# Altium schematic sheet Schematic.SchDoc
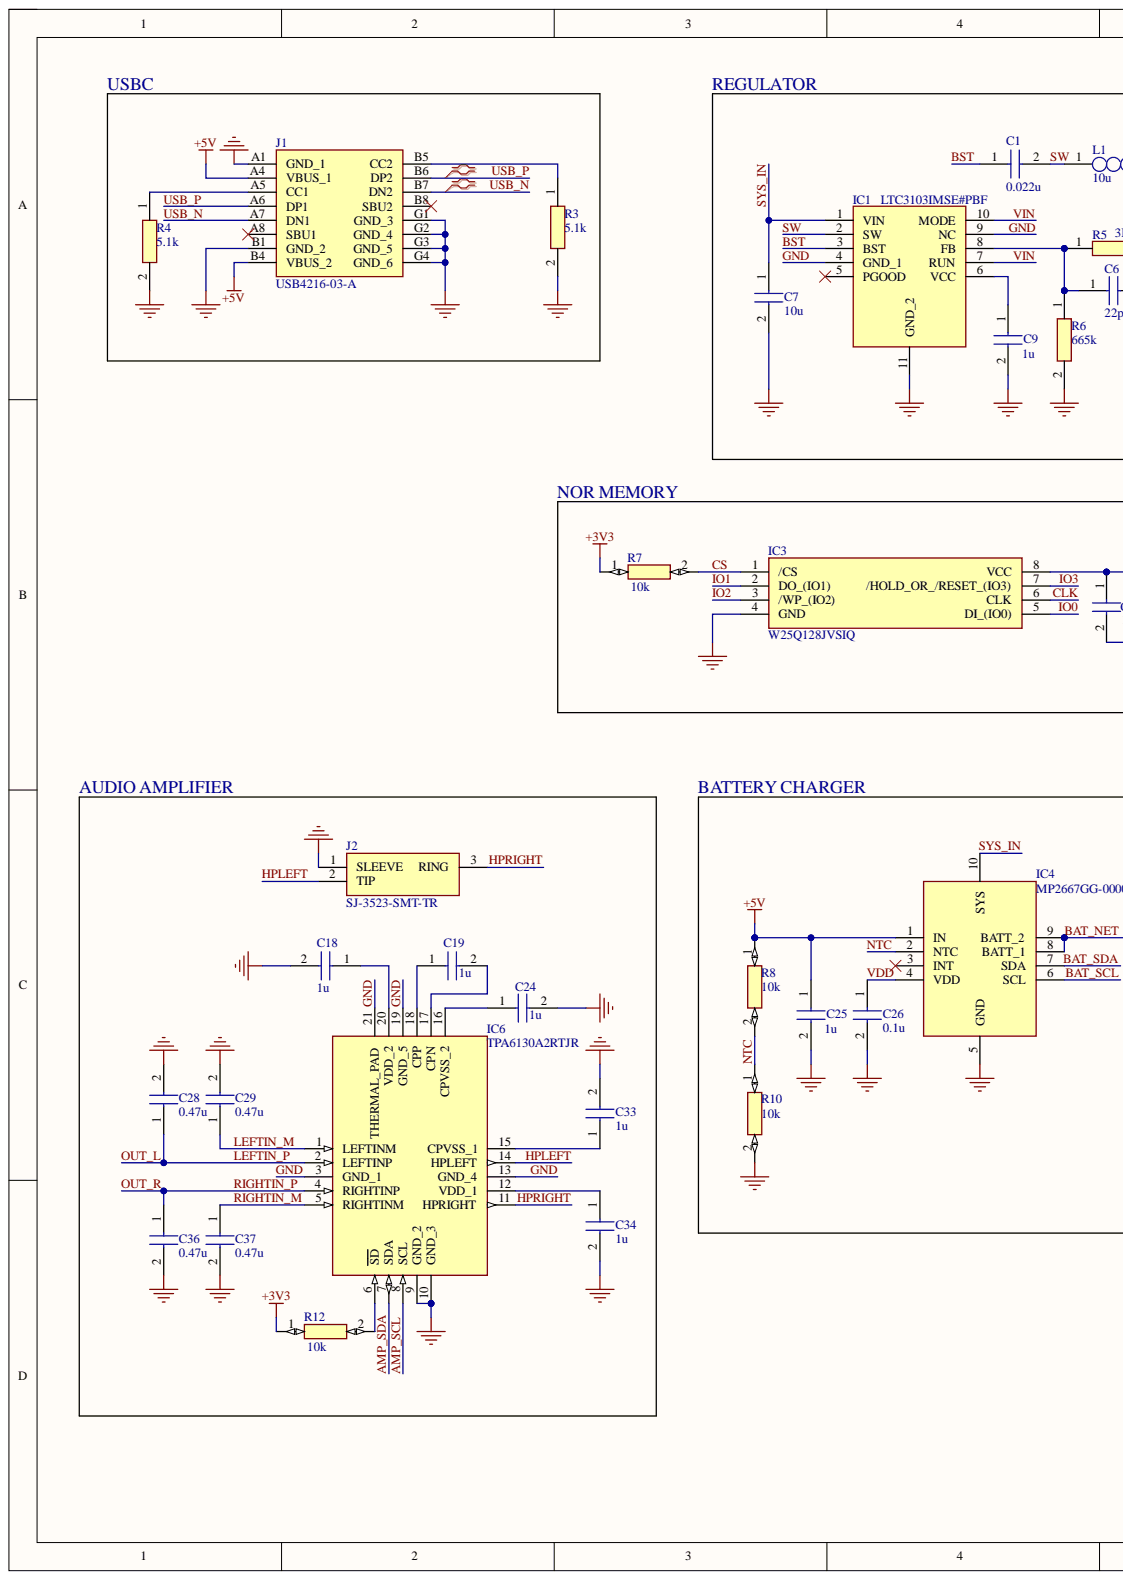
[diagram p0: Schematic.SchDoc, left]
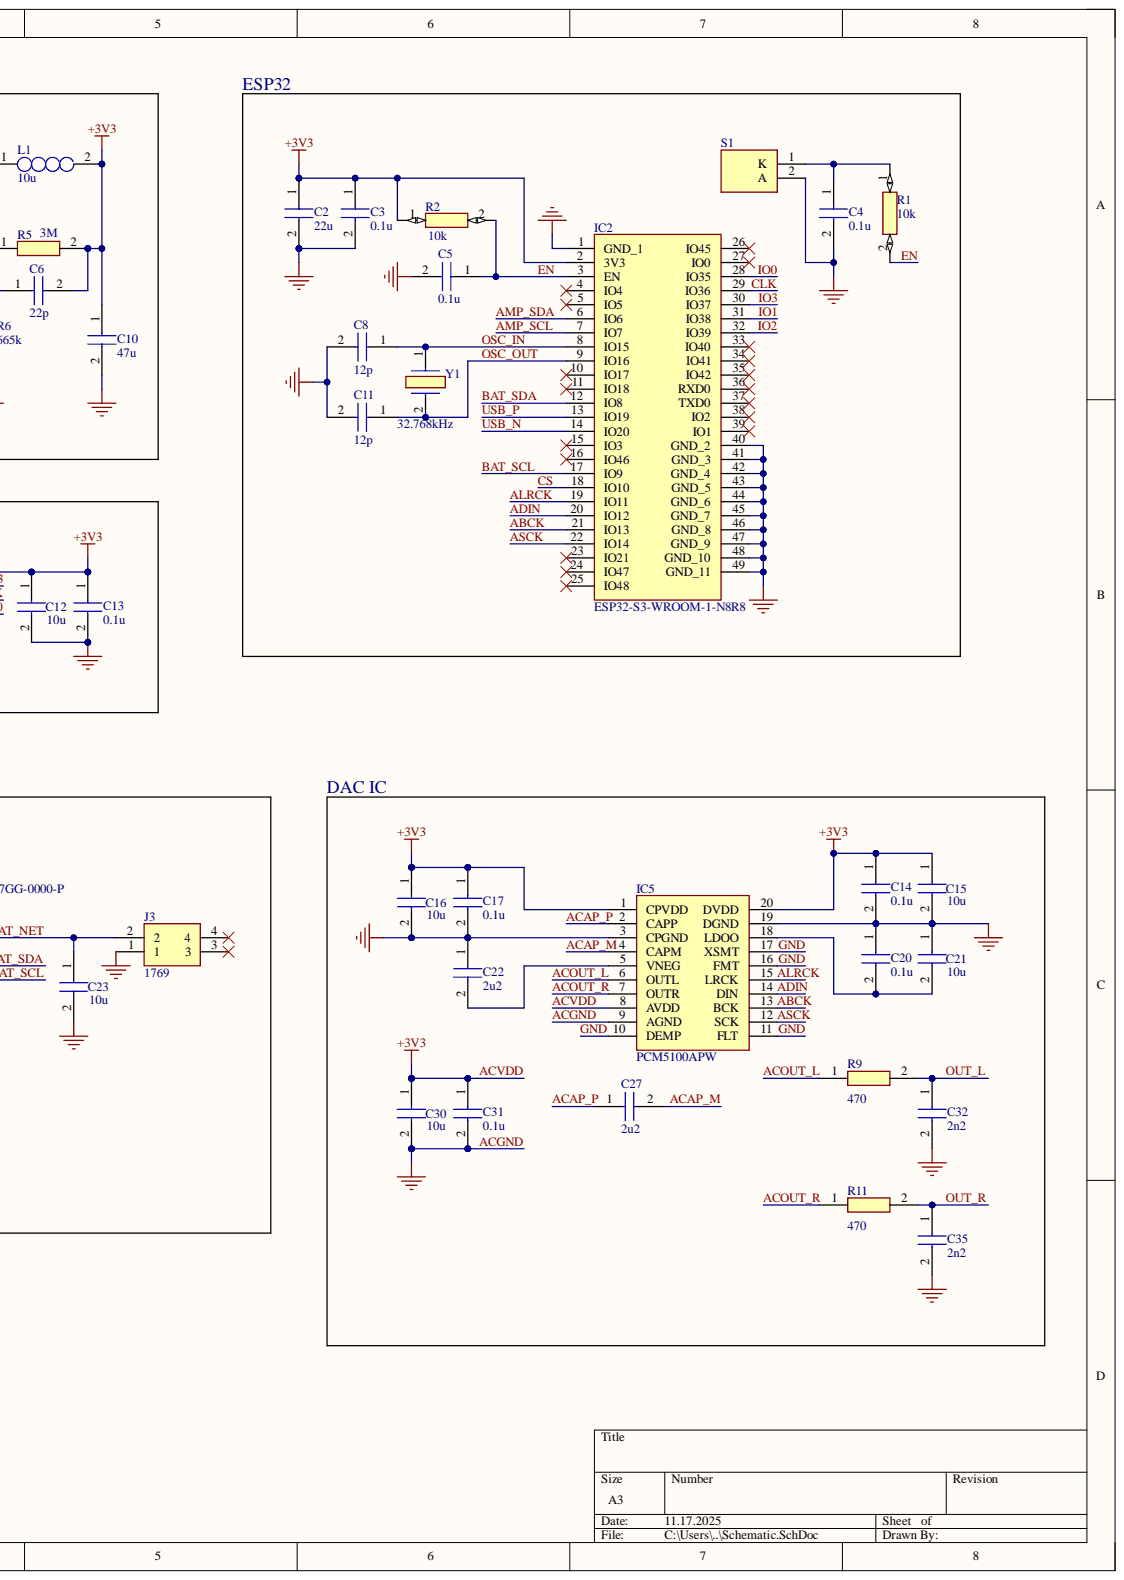
[diagram p1: Schematic.SchDoc, right]

## Parts
C1  0.022u  [CAPC1005X56N]
C2  22u  [MBASx32x]
C3  0.1u  [CAPC1005X55N]
C4  0.1u  [CAPC1005X55N]
C5  0.1u  [CAPC1005X55N]
C6  22p  [CAPC1005X56N]
C7  10u  [GRM18x]
C8  12p  [CAPC1005X70N]
C9  1u  [CAPC1608X90N]
C10  47u  [GRM18x]
C11  12p  [CAPC1005X70N]
C12  10u  [GRM18x]
C13  0.1u  [CAPC1005X55N]
C14  0.1u  [CAPC1005X55N]
C15  10u  [GRM18x]
C16  10u  [GRM18x]
C17  0.1u  [CAPC1005X55N]
C18  1u  [CAPC1608X90N]
C19  1u  [CAPC1608X90N]
C20  0.1u  [CAPC1005X55N]
C21  10u  [GRM18x]
C22  2u2  [GRM15x]
C23  10u  [GRM18x]
C24  1u  [CAPC1608X90N]
C25  1u  [CAPC1608X90N]
C26  0.1u  [CAPC1005X55N]
C27  2u2  [GRM15x]
C28  0.47u  [CAPC1005X56N]
C29  0.47u  [CAPC1005X56N]
C30  10u  [GRM18x]
C31  0.1u  [CAPC1005X55N]
C32  2n2  [CAPC1005X56N]
C33  1u  [CAPC1608X90N]
C34  1u  [CAPC1608X90N]
C35  2n2  [CAPC1005X56N]
C36  0.47u  [CAPC1005X56N]
C37  0.47u  [CAPC1005X56N]
IC1  LTC3103IMSE#PBF  [SOP50P490X110-11N]
IC2  ESP32-S3-WROOM-1-N8R8  [ESP32S3WROOM1N8R8]
IC3  W25Q128JVSIQ  [SOIC127P790X216-8N]
IC4  MP2667GG-0000-P  [QFN50P200X200X105-10N-D]
IC5  PCM5100APW  [SOP65P640X120-20N]
IC6  TPA6130A2RTJR  [QFN50P400X400X80-21N-D]
J1  USB4216-03-A  [USB421603A]
J2  SJ-3523-SMT-TR  [SJ3523SMTTR]
J3  1769  [1769]
L1  10u  [INDM126X95N]
R1  10k  [RESC1005X35N]
R2  10k  [RESC1005X35N]
R3  5.1k  [CRCW0402200KFKED]
R4  5.1k  [CRCW0402200KFKED]
R5  3M  [CRCW0402200KFKED]
R6  665k  [RESC1005X40N]
R7  10k  [RESC1005X35N]
R8  10k  [RESC1005X35N]
R9  470  [ERJ2RKD1004X]
R10  10k  [RESC1005X35N]
R11  470  [ERJ2RKD1004X]
R12  10k  [RESC1005X35N]
S1  B3U-1000P  [B3U-1000P]  (value not drawn)
Y1  32.768kHz  [CM7V32768TBQA020]

## Nets
+5V: C25.1 IC4.1(IN) J1.A4(VBUS_1) J1.B4(VBUS_2) R8.1
ABCK: IC2.21(IO13) IC5.13(BCK)
ACAP_M: C27.2 IC5.4(CAPM)
ACAP_P: C27.1 IC5.2(CAPP)
ACGND: C2.2 C3.2 C4.2 C5.2 C7.2 C8.2 C9.2 C10.2 C11.2 C12.2 C13.2 C14.2 C15.2 C16.2 C17.2 C18.2 C20.1 C21.1 C22.1 C23.2 C24.2 C25.2 C26.2 C28.2 C29.2 C30.2 C31.2 C32.2 C33.2 C34.2 C35.2 C36.2 C37.2 IC1.4(GND_1) IC1.9(NC) IC1.11(GND_2) IC2.1(GND_1) IC2.40(GND_2) IC2.41(GND_3) IC2.42(GND_4) IC2.43(GND_5) IC2.44(GND_6) IC2.45(GND_7) IC2.46(GND_8) IC2.47(GND_9) IC2.48(GND_10) IC2.49(GND_11) IC3.4(GND) IC4.5(GND) IC5.3(CPGND) IC5.9(AGND) IC5.10(DEMP) IC5.11(FLT) IC5.16(FMT) IC5.17(XSMT) IC5.19(DGND) IC6.3(GND_1) IC6.9(GND_2) IC6.10(GND_3) IC6.13(GND_4) IC6.19(GND_5) IC6.21(THERMAL_PAD) J1.A1(GND_1) J1.B1(GND_2) J1.G1(GND_3) J1.G2(GND_4) J1.G3(GND_5) J1.G4(GND_6) J2.1 J3.1 R3.2 R4.2 R6.2 R10.2 S1.2
ACOUT_L: IC5.6(OUTL) R9.1
ACOUT_R: IC5.7(OUTR) R11.1
ACVDD: C2.1 C3.1 C6.2 C10.1 C12.1 C13.1 C14.1 C15.1 C16.1 C17.1 C30.1 C31.1 IC2.2(3V3) IC3.8(VCC) IC5.1(CPVDD) IC5.8(AVDD) IC5.20(DVDD) L1.2 R2.1 R5.2 R7.1 R12.1
ADIN: IC2.20(IO12) IC5.14(DIN)
ALRCK: IC2.19(IO11) IC5.15(LRCK)
AMP_SCL: IC2.7(IO7) IC6.8(SCL)
AMP_SDA: IC2.6(IO6) IC6.7(SDA)
ASCK: IC2.22(IO14) IC5.12(SCK)
BAT_NET: C23.1 IC4.8(BATT_1) IC4.9(BATT_2) J3.2
BAT_SCL: IC2.17(IO9) IC4.6(SCL)
BAT_SDA: IC2.12(IO8) IC4.7(SDA)
BST: C1.1 IC1.3(BST)
CLK: IC2.29(IO36) IC3.6(CLK)
CS: IC2.18(IO10) IC3.1(/CS) R7.2
EN: C5.1 IC2.3(EN) R1.2 R2.2
HPLEFT: IC6.14(HPLEFT) J2.2
HPRIGHT: IC6.11(HPRIGHT) J2.3
IO0: IC2.28(IO35) IC3.5(DI_(IO0))
IO1: IC2.31(IO38) IC3.2(DO_(IO1))
IO2: IC2.32(IO39) IC3.3(/WP_(IO2))
IO3: IC2.30(IO37) IC3.7(/HOLD_OR_/RESET_(IO3))
LEFTIN_M: C29.1 IC6.1(LEFTINM)
LEFTIN_P: C28.1 C32.1 IC6.2(LEFTINP) R9.2
NTC: IC4.2(NTC) R8.2 R10.1
OSC_IN: C8.1 IC2.8(IO15) Y1.1
OSC_OUT: C11.1 IC2.9(IO16) Y1.2
OUT_R: C35.1 C36.1 IC6.4(RIGHTINP) R11.2
RIGHTIN_M: C37.1 IC6.5(RIGHTINM)
SW: C1.2 IC1.2(SW) L1.1
SYS_IN: C7.1 IC1.1(VIN) IC4.10(SYS)
USB_N: IC2.14(IO20) J1.A7(DN1) J1.B7(DN2)
USB_P: IC2.13(IO19) J1.A6(DP1) J1.B6(DP2)
VDD: C26.1 IC4.4(VDD)
VIN: IC1.7(RUN) IC1.10(MODE)
NetC4_1: C4.1 R1.1 S1.1
NetC6_1: C6.1 IC1.8(FB) R5.1 R6.1
NetC9_1: C9.1 IC1.6(VCC)
NetC18_1: C18.1 IC6.20(VDD_2)
NetC19_1: C19.1 IC6.18(CPP)
NetC19_2: C19.2 IC6.17(CPN)
NetC20_2: C20.2 C21.2 IC5.18(LDOO)
NetC22_2: C22.2 IC5.5(VNEG)
NetC24_1: C24.1 IC6.16(CPVSS_2)
NetC33_1: C33.1 IC6.15(CPVSS_1)
NetC34_1: C34.1 IC6.12(VDD_1)
NetJ1_A5: J1.A5(CC1) R4.1
NetJ1_B5: J1.B5(CC2) R3.1
NetR12_2: IC6.6(SD) R12.2

Not connected: IC1 5(PGOOD); IC2 4(IO4) 5(IO5) 10(IO17) 11(IO18) 15(IO3) 16(IO46) 23(IO21) 24(IO47) 25(IO48) 26(IO45) 27(IO0) 33(IO40) 34(IO41) 35(IO42) 36(RXD0) 37(TXD0) 38(IO2) 39(IO1); IC4 3(INT); J1 A8(SBU1) B8(SBU2); J3 3 4

## Library data of the parts
C1 = 04023C223KAT2A: Capacitor; Manufacturer_Name: Kyocera AVX; Component Kind: Standard; Datasheet Link: http://www.mouser.com/catalog/supplier/library/pdf/AVXSurfaceMountCeramic.pdf; Height: 0.56mm; Manufacturer_Part_Number: 04023C223KAT2A; Mouser Part Number: 581-04023C223KAT2A; Mouser Price/Stock: https://www.mouser.co.uk/ProductDetail/KYOCERA-AVX/04023C223KAT2A?qs=ZBeOGGPQgEFqkGmN25OUXQ%3D%3D
C2 = MBASL32MSB7226KPNA01: Capacitor; Manufacturer_Name: TAIYO YUDEN; Component Kind: Standard; Datasheet Link: https://ds.yuden.co.jp/TYCOMPAS/or/detail?pn=MBASL32MSB7226KPNA01; Height: 2.5mm; Manufacturer_Part_Number: MBASL32MSB7226KPNA01; Mouser Part Number: 963-BASL32MSB7226KP; Mouser Price/Stock: https://www.mouser.co.uk/ProductDetail/TAIYO-YUDEN/MBASL32MSB7226KPNA01?qs=tlsG%2FOw5FFjUIh8N4C%252Bpug%3D%3D
C3-C5 C13 C14 C17 C20 C26 C31 = LMK105B7104KVHF: Capacitor; Manufacturer_Name: TAIYO YUDEN; Component Kind: Standard; Datasheet Link: https://www.yuden.co.jp/productdata/catalog/mlcc_all_hq_e.pdf; Height: 0.55mm; Manufacturer_Part_Number: LMK105B7104KVHF; Mouser Part Number: 963-LMK105B7104KVHF; Mouser Price/Stock: https://www.mouser.co.uk/ProductDetail/Taiyo-Yuden/LMK105B7104KVHF?qs=qPaGMJUC%252BSuqfdpnzW7iCg%3D%3D
C6 = 04025C220JAT2A: Capacitor; Manufacturer_Name: Kyocera AVX; Arrow Part Number: 04025C220JAT2A; Arrow Price/Stock: https://www.arrow.com/en/products/04025c220jat2a/avx?utm_currency=USD&region=nac; Component Kind: Standard; Datasheet Link: http://www.mouser.com/catalog/supplier/library/pdf/AVXSurfaceMountCeramic.pdf; Height: 0.56mm; Manufacturer_Part_Number: 04025C220JAT2A; Mouser Part Number: 581-04025C220JAT2A; Mouser Price/Stock: https://www.mouser.co.uk/ProductDetail/KYOCERA-AVX/04025C220JAT2A?qs=AQlKX63v8Rv5YG6O1vwOtA%3D%3D
C7 C12 C15 C16 C21 C23 C30 = GRM188Z71A106KA73D: Capacitor; Manufacturer_Name: Murata Electronics; Arrow Part Number: GRM188Z71A106KA73D; Arrow Price/Stock: https://www.arrow.com/en/products/grm188z71a106ka73d/murata-manufacturing?utm_currency=USD&region=europe; Component Kind: Standard; Datasheet Link: https://search.murata.co.jp/Ceramy/image/img/A01X/G101/ENG/GRM188Z71A106KA73-01A.pdf; Height: 0.9mm; Manufacturer_Part_Number: GRM188Z71A106KA73D; Mouser Part Number: 81-GRM188Z71A106KA3D; Mouser Price/Stock: https://www.mouser.co.uk/ProductDetail/Murata-Electronics/GRM188Z71A106KA73D?qs=d0WKAl%252BL4KaRM1L%252BaIs%2FqQ%3D%3D
C8 C11 = GRM1555C1E120GA01D: Capacitor; Manufacturer_Name: Murata Electronics; Arrow Part Number: GRM1555C1E120GA01D; Arrow Price/Stock: https://www.arrow.com/en/products/grm1555c1e120ga01d/murata-manufacturing; Component Kind: Standard; Datasheet Link: https://search.murata.co.jp/Ceramy/image/img/A01X/G101/ENG/GRM1555C1E120GA01-01A.pdf; Height: 0.7mm; Manufacturer_Part_Number: GRM1555C1E120GA01D; Mouser Part Number: 81-GRM1555C1E120GA1D; Mouser Price/Stock: https://www.mouser.co.uk/ProductDetail/Murata-Electronics/GRM1555C1E120GA01D?qs=ouTquLLW2S6JuQyCSOlgDw%3D%3D
C9 C18 C19 C24 C25 C33 C34 = CMP-001-00136-1: Capacitor; Manufacturer_Name: AVX; Manufacturer_Part_Number: 0603YC105KAT2A; Arrow Part Number: 0603YC105KAT2A; Arrow Price/Stock: https://www.arrow.com/en/products/0603yc105kat2a/avx?region=nac; Component Kind: Standard; Datasheet Link: https://componentsearchengine.com/Datasheets/1/06031A100FAT2A.pdf; Design Item ID: 0603YC105KAT2A; Height: 0.9mm; Mouser Part Number: 581-0603YC105KAT2A; Mouser Price/Stock: https://www.mouser.co.uk/ProductDetail/AVX/0603YC105KAT2A?qs=oZHZyJ9sPsF0nYEZ1SOhJA%3D%3D; Source: SamacSys.SchLib; Library Name: H2polito
C10 = GRM188R60J476ME15D: Capacitor; Manufacturer_Name: Murata Electronics; Arrow Part Number: GRM188R60J476ME15D; Arrow Price/Stock: https://www.arrow.com/en/products/grm188r60j476me15d/murata-manufacturing?utm_currency=USD&region=nac; Component Kind: Standard; Datasheet Link: https://search.murata.co.jp/Ceramy/image/img/A01X/G101/ENG/GRM188R60J476ME15-01A.pdf; Height: 0.9mm; Manufacturer_Part_Number: GRM188R60J476ME15D; Mouser Part Number: 81-GRM188R60J476ME5D; Mouser Price/Stock: https://www.mouser.co.uk/ProductDetail/Murata-Electronics/GRM188R60J476ME15D?qs=AEetWsPH0It1A1GvJsMuyQ%3D%3D
C22 C27 = GRM155Z71A225KE44D: Capacitor; Manufacturer_Name: Murata Electronics; Arrow Part Number: GRM155Z71A225KE44D; Arrow Price/Stock: https://www.arrow.com/en/products/grm155z71a225ke44d/murata-manufacturing?region=europe; Component Kind: Standard; Datasheet Link: https://search.murata.co.jp/Ceramy/image/img/A01X/G101/ENG/GRM155Z71A225KE44-01A.pdf; Height: 0.33mm; Manufacturer_Part_Number: GRM155Z71A225KE44D; Mouser Part Number: 81-GRM155Z71A225KE4D; Mouser Price/Stock: https://www.mouser.co.uk/ProductDetail/Murata-Electronics/GRM155Z71A225KE44D?qs=lEfY3O89AqkL%252BdwAD2fAKQ%3D%3D
C28 C29 C36 C37 = 0402ZC474KAT2A: Capacitor; Manufacturer_Name: Kyocera AVX; Component Kind: Standard; Datasheet Link: http://www.mouser.com/catalog/supplier/library/pdf/AVXSurfaceMountCeramic.pdf; Height: 0.56mm; Manufacturer_Part_Number: 0402ZC474KAT2A; Mouser Part Number: 581-0402ZC474KAT2A; Mouser Price/Stock: https://www.mouser.co.uk/ProductDetail/AVX/0402ZC474KAT2A?qs=%252BdQmOuGyFcEGJFSGKwkrCw%3D%3D
C32 C35 = 04023C222KAT2A: Capacitor; Manufacturer_Name: Kyocera AVX; Arrow Part Number: 04023C222KAT2A; Arrow Price/Stock: https://www.arrow.com/en/products/04023c222kat2a/avx?utm_currency=USD&region=nac; Component Kind: Standard; Datasheet Link: http://www.mouser.com/catalog/supplier/library/pdf/AVXSurfaceMountCeramic.pdf; Height: 0.56mm; Manufacturer_Part_Number: 04023C222KAT2A; Mouser Part Number: 581-04023C222KAT2A; Mouser Price/Stock: https://www.mouser.co.uk/ProductDetail/KYOCERA-AVX/04023C222KAT2A?qs=KeJ6wBBJrrorroMRPCeIVQ%3D%3D
IC1 = LTC3103IMSE#PBF: Integrated Circuit; Manufacturer_Name: Analog Devices; Arrow Part Number: LTC3103IMSE#PBF; Arrow Price/Stock: https://www.arrow.com/en/products/ltc3103imsepbf/analog-devices; Component Kind: Standard; Datasheet Link: https://componentsearchengine.com/Datasheets/1/LTC3103IMSE#PBF.pdf; Height: 1.1mm; Manufacturer_Part_Number: LTC3103IMSE#PBF; Mouser Part Number: 584-LTC3103IMSE#PBF; Mouser Price/Stock: https://www.mouser.com/Search/Refine.aspx?Keyword=584-LTC3103IMSE%23PBF
IC2 = ESP32-S3-WROOM-1-N8R8: Integrated Circuit; Manufacturer_Name: Espressif Systems; Component Kind: Standard; Datasheet Link: https://www.espressif.com/sites/default/files/documentation/esp32-s3-wroom-1_wroom-1u_datasheet_en.pdf; Height: 3.25mm; Manufacturer_Part_Number: ESP32-S3-WROOM-1-N8R8; Mouser Part Number: 356-EP32S3WROOM1N8R8; Mouser Price/Stock: https://www.mouser.co.uk/ProductDetail/Espressif-Systems/ESP32-S3-WROOM-1-N8R8?qs=7D1LtPJG0i3%2F28hKFavOpQ%3D%3D
IC3 = W25Q128JVSIQ: Integrated Circuit; Manufacturer_Name: Winbond; Arrow Part Number: W25Q128JVSIQ; Arrow Price/Stock: https://www.arrow.com/en/products/w25q128jvsiq/winbond-electronics?utm_currency=USD&region=nac; Component Kind: Standard; Datasheet Link: https://www.winbond.com/hq/search-resource-file.jsp?partNo=W25Q128JVSIQ&type=datasheet; Height: 2.16mm; Manufacturer_Part_Number: W25Q128JVSIQ; Mouser Part Number: 454-W25Q128JVSIQ; Mouser Price/Stock: https://www.mouser.co.uk/ProductDetail/Winbond/W25Q128JVSIQ?qs=qSfuJ%252Bfl%2Fd6tLXvPR4fl9w%3D%3D
IC4 = MP2667GG-0000-P: Integrated Circuit; Manufacturer_Name: Monolithic Power Systems (MPS); Component Kind: Standard; Datasheet Link: http://www.farnell.com/datasheets/2914931.pdf; Height: 1.05mm; Manufacturer_Part_Number: MP2667GG-0000-P; Mouser Part Number: 946-MP2667GG-0000-P; Mouser Price/Stock: https://www.mouser.co.uk/ProductDetail/Monolithic-Power-Systems-MPS/MP2667GG-0000-P?qs=%2F4dsY8i%2FUxK26ddm8WbL%252Bg%3D%3D
IC5 = PCM5100APW: Integrated Circuit; Manufacturer_Name: Texas Instruments; Arrow Part Number: PCM5100APW; Arrow Price/Stock: https://www.arrow.com/en/products/pcm5100apw/texas-instruments?region=europe; Component Kind: Standard; Datasheet Link: http://www.ti.com/lit/ds/symlink/pcm5100a.pdf; Height: 1.2mm; Manufacturer_Part_Number: PCM5100APW; Mouser Part Number: 595-PCM5100APW; Mouser Price/Stock: https://www.mouser.co.uk/ProductDetail/Texas-Instruments/PCM5100APW?qs=E2%2FxqS9xjzq3cBs2gonHQQ%3D%3D
IC6 = TPA6130A2RTJR: Integrated Circuit; Manufacturer_Name: Texas Instruments; Arrow Part Number: TPA6130A2RTJR; Arrow Price/Stock: https://www.arrow.com/en/products/tpa6130a2rtjr/texas-instruments?utm_currency=USD&region=nac; Component Kind: Standard; Datasheet Link: https://www.ti.com/lit/ds/symlink/tpa6130a2.pdf; Height: 0.8mm; Manufacturer_Part_Number: TPA6130A2RTJR; Mouser Part Number: 595-TPA6130A2RTJR; Mouser Price/Stock: https://www.mouser.co.uk/ProductDetail/Texas-Instruments/TPA6130A2RTJR?qs=7kv20MEVUlgikVnGJs4DSg%3D%3D
J1 = USB4216-03-A: Connector; Manufacturer_Name: GCT (GLOBAL CONNECTOR TECHNOLOGY); Component Kind: Standard; Datasheet Link: https://eu.mouser.com/datasheet/2/837/usb4216-3577180.pdf; Manufacturer_Part_Number: USB4216-03-A; Mouser Part Number: 640-USB4216-03-A; Mouser Price/Stock: https://www.mouser.co.uk/ProductDetail/GCT/USB4216-03-A?qs=Tc%252BHE9vUsnv1G2UWLFIexA%3D%3D
J2 = SJ-3523-SMT-TR: Connector; Manufacturer_Name: Same Sky; Arrow Part Number: SJ-3523-SMT-TR; Arrow Price/Stock: https://www.arrow.com/en/products/sj-3523-smt-tr/cui-devices?utm_currency=USD&region=europe; Component Kind: Standard; Datasheet Link: https://www.sameskydevices.com/product/resource/sj-352x-smt.pdf; Height: 5.2mm; Manufacturer_Part_Number: SJ-3523-SMT-TR; Mouser Part Number: 490-SJ-3523-SMT-TR; Mouser Price/Stock: https://www.mouser.co.uk/ProductDetail/CUI-Devices/SJ-3523-SMT-TR?qs=WyjlAZoYn51zOHzJ3r4ZRA%3D%3D
J3 = 1769: Connector; Manufacturer_Name: Adafruit; Arrow Part Number: 1769; Arrow Price/Stock: https://www.arrow.com/en/products/1769/adafruit-industries?utm_currency=USD&region=nac; Component Kind: Standard; Datasheet Link: https://cdn-shop.adafruit.com/datasheets/17311.pdf; Height: 5.5mm; Manufacturer_Part_Number: 1769; Mouser Part Number: 485-1769; Mouser Price/Stock: https://www.mouser.co.uk/ProductDetail/Adafruit/1769?qs=GURawfaeGuBbgoGtf2XstA%3D%3D
L1 = PLEC69BCA100M-1PT00: Inductor; Manufacturer_Name: TDK; Component Kind: Standard; Datasheet Link: https://www.mouser.com/catalog/specsheets/TDK_inductor_commercial_power_6-9-2025.pdf?_gl=1*1kfectw*_gcl_aw*R0NMLjE3NTkxMzgxMTkuQ2owS0NRanczT2pHQmhEWUFSSXNBRGQtdVg2R2xNX21oRnpQMVFFVXlHclBKSzJEb1drU2xqMVZzdTROWDFXUzRNUndqNFU3YWdOZk1hWWFBbUphRUFMd193Y0I.*_gc; Height: 0.95mm; Manufacturer_Part_Number: PLEC69BCA100M-1PT00
R1 R2 R7 R8 R10 R12 = CRCW040210K0FKEDC: Resistor; Manufacturer_Name: Vishay; Arrow Part Number: CRCW040210K0FKEDC; Arrow Price/Stock: https://www.arrow.com/en/products/crcw040210k0fkedc/vishay?utm_currency=USD&region=nac; Component Kind: Standard; Datasheet Link: https://rs.componentsearchengine.com/Datasheets/2/CRCW040210K0FKEDC.pdf; Height: 0.35mm; Manufacturer_Part_Number: CRCW040210K0FKEDC; Mouser Part Number: 71-CRCW040210K0FKEDC; Mouser Price/Stock: https://www.mouser.co.uk/ProductDetail/Vishay-Dale/CRCW040210K0FKEDC?qs=E3Y5ESvWgWPiNLcGzwCGPg%3D%3D
R3 R4 = CRCW04025K10FKED: Resistor; Manufacturer_Name: Vishay; Arrow Part Number: CRCW04025K10FKED; Arrow Price/Stock: https://www.arrow.com/en/products/crcw04025k10fked/vishay?region=nac; Component Kind: Standard; Datasheet Link: http://www.vishay.com/docs/20035/dcrcwe3.pdf; Height: 0.4mm; Manufacturer_Part_Number: CRCW04025K10FKED; Mouser Part Number: 71-CRCW0402-5.1K-E3; Mouser Price/Stock: https://www.mouser.co.uk/ProductDetail/Vishay-Dale/CRCW04025K10FKED?qs=s0g2FHNyNFj8r6Uw5EJZ%2Fw%3D%3D
R5 = CRCW04023M00FKED: Resistor; Manufacturer_Name: Vishay; Arrow Part Number: CRCW04023M00FKED; Arrow Price/Stock: https://www.arrow.com/en/products/crcw04023m00fked/vishay?utm_currency=USD&region=europe; Component Kind: Standard; Datasheet Link: http://www.vishay.com/docs/20035/dcrcwe3.pdf; Height: 0.4mm; Manufacturer_Part_Number: CRCW04023M00FKED; Mouser Part Number: 71-CRCW04023M00FKED; Mouser Price/Stock: https://www.mouser.co.uk/ProductDetail/Vishay-Dale/CRCW04023M00FKED?qs=%2FGvPWUwWV9ZaGfv5KFeFPQ%3D%3D
R6 = CR0402-FX-6653GLF: Resistor; Manufacturer_Name: Bourns; Component Kind: Standard; Datasheet Link: https://www.bourns.com/pdfs/CR0402.pdf; Height: 0.4mm; Manufacturer_Part_Number: CR0402-FX-6653GLF; Mouser Part Number: 652-CR0402FX6653GLF; Mouser Price/Stock: https://www.mouser.co.uk/ProductDetail/Bourns/CR0402-FX-6653GLF?qs=B0uw3wJAhvs9ruG8jMFAHA%3D%3D
R9 R11 = ERJ-2RHD4700X: Resistor; Manufacturer_Name: Panasonic; Component Kind: Standard; Datasheet Link: https://datasheet.datasheetarchive.com/originals/distributors/DKDS41/DSANUWW0038499.pdf; Height: 0.4mm; Manufacturer_Part_Number: ERJ-2RHD4700X; Mouser Part Number: 667-ERJ-2RHD4700X; Mouser Price/Stock: https://www.mouser.com/Search/Refine.aspx?Keyword=667-ERJ-2RHD4700X
S1 = B3U-1000P: Switch; Manufacturer_Name: OMRON Electronics; Arrow Part Number: B3U-1000P; Arrow Price/Stock: https://www.arrow.com/en/products/b3u1000p/omron?utm_currency=USD&region=europe; Component Kind: Standard; Datasheet Link: https://www.omron.com/ecb/products/pdf/en-b3u.pdf; Manufacturer_Part_Number: B3U-1000P; Mouser Part Number: 653-B3U-1000P; Mouser Price/Stock: https://www.mouser.co.uk/ProductDetail/Omron-Electronics/B3U-1000P?qs=AO7BQMcsEu4ip80xyf2FwA%3D%3D
Y1 = CM7V-T1A-0.3-32.768kHz-9pF-20PPM-TA-QC: Crystal or Oscillator; Manufacturer_Name: Micro Crystal AG; Component Kind: Standard; Datasheet Link: https://www.mouser.de/datasheet/2/530/CM7V_T1A-1957503.pdf; Height: 0.65mm; Manufacturer_Part_Number: CM7V-T1A-0.3-32.768kHz-9pF-20PPM-TA-QC; Mouser Part Number: 428-202958-MG01; Mouser Price/Stock: https://www.mouser.co.uk/ProductDetail/Micro-Crystal/CM7V-T1A-0.3-32.768kHz-9pF-20PPM-TA-QC?qs=7bTaA%2FLYtSYdNnOeUSDudw%3D%3D
